# Altium schematic sheet Receiver.SchDoc(Receiver)
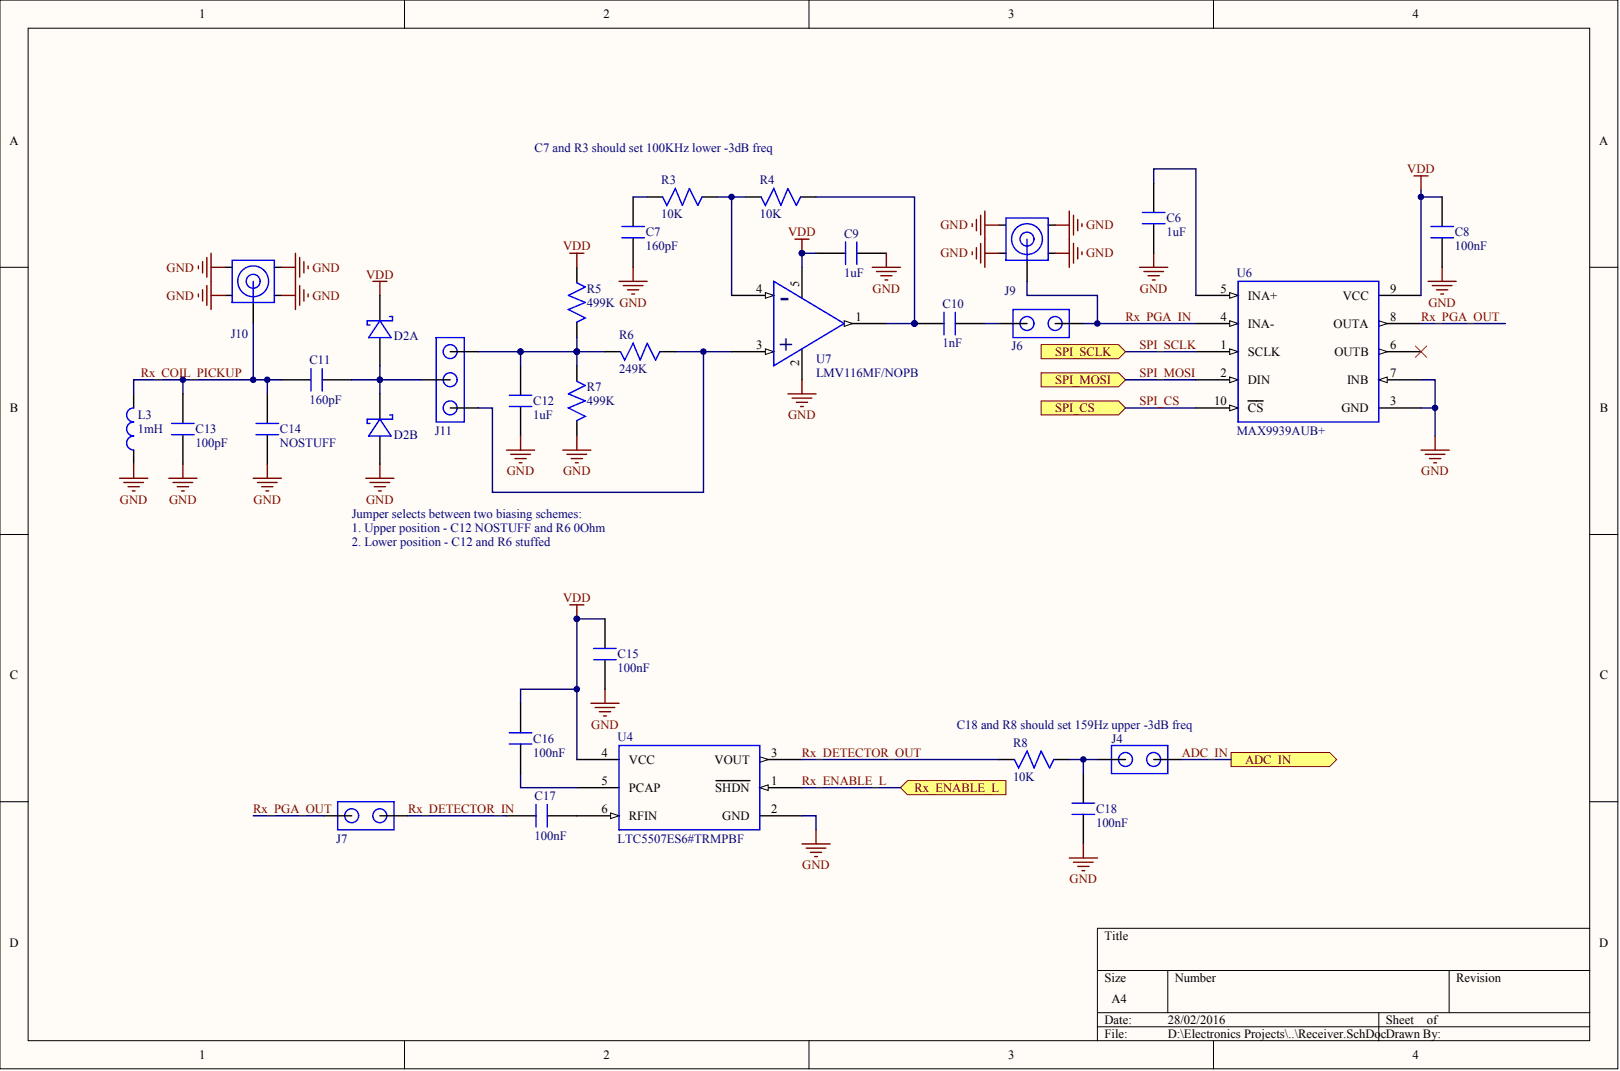
[diagram p0: Receiver.SchDoc]

## Parts
C6  Capacitance: 1uF  (hidden comment: CAP_0402_1uF)
C7  Capacitance: 160pF  (hidden comment: CAP_0402_160p)
C8  Capacitance: 100nF  (hidden comment: CAP_0402_100nF)
C9  Capacitance: 1uF  (hidden comment: CAP_0402_1uF)
C10  Capacitance: 1nF  (hidden comment: CAP_0402_1nF)
C11  Capacitance: 160pF  (hidden comment: CAP_0402_160p)
C12  Capacitance: 1uF  (hidden comment: CAP_0402_1uF)
C13  Capacitance: 100pF  (hidden comment: CAP_0603_100pF)
C14  CAP_0402_NOSTUFF  (value not drawn)
C15  Capacitance: 100nF  (hidden comment: CAP_0402_100nF)
C16  Capacitance: 100nF  (hidden comment: CAP_0402_100nF)
C17  Capacitance: 100nF  (hidden comment: CAP_0402_100nF)
C18  Capacitance: 100nF  (hidden comment: CAP_0402_100nF)
D2  DIODE_1PS70SB84  (value not drawn)  (parts A,B)
J4  CONN_2PIN_HEADER  (value not drawn)
J6  CONN_2PIN_HEADER  (value not drawn)
J7  CONN_2PIN_HEADER  (value not drawn)
J9  CONN_SMA_EDGEMOUNT  (value not drawn)
J10  CONN_SMA_EDGEMOUNT  (value not drawn)
J11  CONN_3PIN_HEADER  (value not drawn)
L3  Inductance: 1mH  (hidden comment: IND_TH_1mH)
R3  Resistance: 10K  (hidden comment: RES_0402_10K)
R4  Resistance: 10K  (hidden comment: RES_0402_10K)
R5  Resistance: 499K  (hidden comment: RES_0402_499K)
R6  Resistance: 249K  (hidden comment: RES_0402_249K)
R7  Resistance: 499K  (hidden comment: RES_0402_499K)
R8  Resistance: 10K  (hidden comment: RES_0402_10K)
U4  LTC5507  Part Number: LTC5507ES6#TRMPBF
U6  MAX9939  Part Number: MAX9939AUB+
U7  LMV116  Part Number: LMV116MF/NOPB

## Ports
ADC_IN, RX_ENABLE_L, SPI_CS, SPI_MOSI, SPI_SCLK

## Nets
GND: C6.1 C7.1 C8.1 C9.1 C12.1 C13.1 C14.1 C15.1 C18.1 D2.1 J9.1 J9.2 J9.3 J9.4 J10.1 J10.2 J10.3 J10.4 L3.1 R7.1 U4.2(GND) U6.3(GND) U6.7(INB) U7.2
VDD: C8.2 C9.2 C15.2 C16.2 D2.2 R5.2 U4.4(VCC) U6.9(VCC) U7.5
ADC_IN: J4.2 ; port ADC_IN
RX_COIL_PICKUP: C11.1 C13.2 C14.2 J10.5 L3.2
RX_DETECTOR_IN: C17.1 J7.2
RX_DETECTOR_OUT: R8.1 U4.3(VOUT)
RX_ENABLE_L: U4.1(SHDN) ; port RX_ENABLE_L
RX_PGA_IN: J6.2 J9.5 U6.4(INA-)
RX_PGA_OUT: J7.1 U6.8(OUTA)
SPI_CS: U6.10(CS) ; port SPI_CS
SPI_MOSI: U6.2(DIN) ; port SPI_MOSI
SPI_SCLK: U6.1(SCLK) ; port SPI_SCLK
NetC6_2: C6.2 U6.5(INA+)
NetC7_2: C7.2 R3.1
NetC10_1: C10.1 R4.2 U7.1
NetC10_2: C10.2 J6.1
NetC11_2: C11.2 D2.3 J11.2
NetC12_2: C12.2 J11.1 R5.1 R6.1 R7.2
NetC16_1: C16.1 U4.5(PCAP)
NetC17_2: C17.2 U4.6(RFIN)
NetC18_2: C18.2 J4.1 R8.2
NetJ11_3: J11.3 R6.2 U7.3(+)
NetR3_2: R3.2 R4.1 U7.4(-)

Not connected: U6 6(OUTB)

## Library data of the parts
C6 C9 C12 = (no symbol name): Manufacturer: TDK; Part Number: C1005X5R1A105K050BB; Tolerance: 10%; Voltage: 10V
C7 C11 = (no symbol name): Manufacturer: Murata; Part Number: GRM1555C1H161JA01D; Tolerance: 5%; Voltage: 50V
C8 C15-C18 = (no symbol name): Manufacturer: TDK; Part Number: C1005X5R1A104K050BA; Tolerance: 10%; Voltage: 10V
C10 = (no symbol name): Manufacturer: Murata; Part Number: GRM1555C1H102JA01D; Tolerance: 5%; Voltage: 50V
C13 = (no symbol name): Manufacturer: Kemet; Part Number: C0603C101K5RACTU; Tolerance: 10%; Voltage: 50V
D2 = (no symbol name): Manufacturer: NXP Semiconductor; Part Number: 1PS70SB84,115; Package: SOT-323
J4 J6 J7 = (no symbol name): Manufacturer: 3M; Part Number: 961102-6404-AR
J11 = (no symbol name): Manufacturer: 3M; Part Number: 951103-8622-AR
L3 = (no symbol name): Manufacturer: Bourns; Part Number: RLB0608-102KL; DCR: 11.5Ohm
R3 R4 = (no symbol name): Manufacturer: Stackpole Electronics; Part Number: RMCF0402FT10K0; Tolerance: 1%
R5 R7 = (no symbol name): Manufacturer: Vishay Dale; Part Number: CRCW0402499KFKED; Tolerance: 1%
R6 = (no symbol name): Manufacturer: Vishay Dale; Part Number: CRCW0402249KFKED; Tolerance: 1%
R8 = (no symbol name): Manufacturer: Vishay Dale; Part Number: CRCW040210K0FKED; Tolerance: 1%
U4 = (no symbol name): Manufacturer: Linear Technology; Package: TSOT-23
U6 = (no symbol name): Manufacturer: Maxim; Package: uMAX-10
U7 = (no symbol name): Manufacturer: Texas Instruments; Package: SOT-23-5
C14 J9 J10 = (no symbol name)
  C14: NOSTUFF: NOSTUFF
